# Altium schematic sheet L6790A_Si.SchDoc
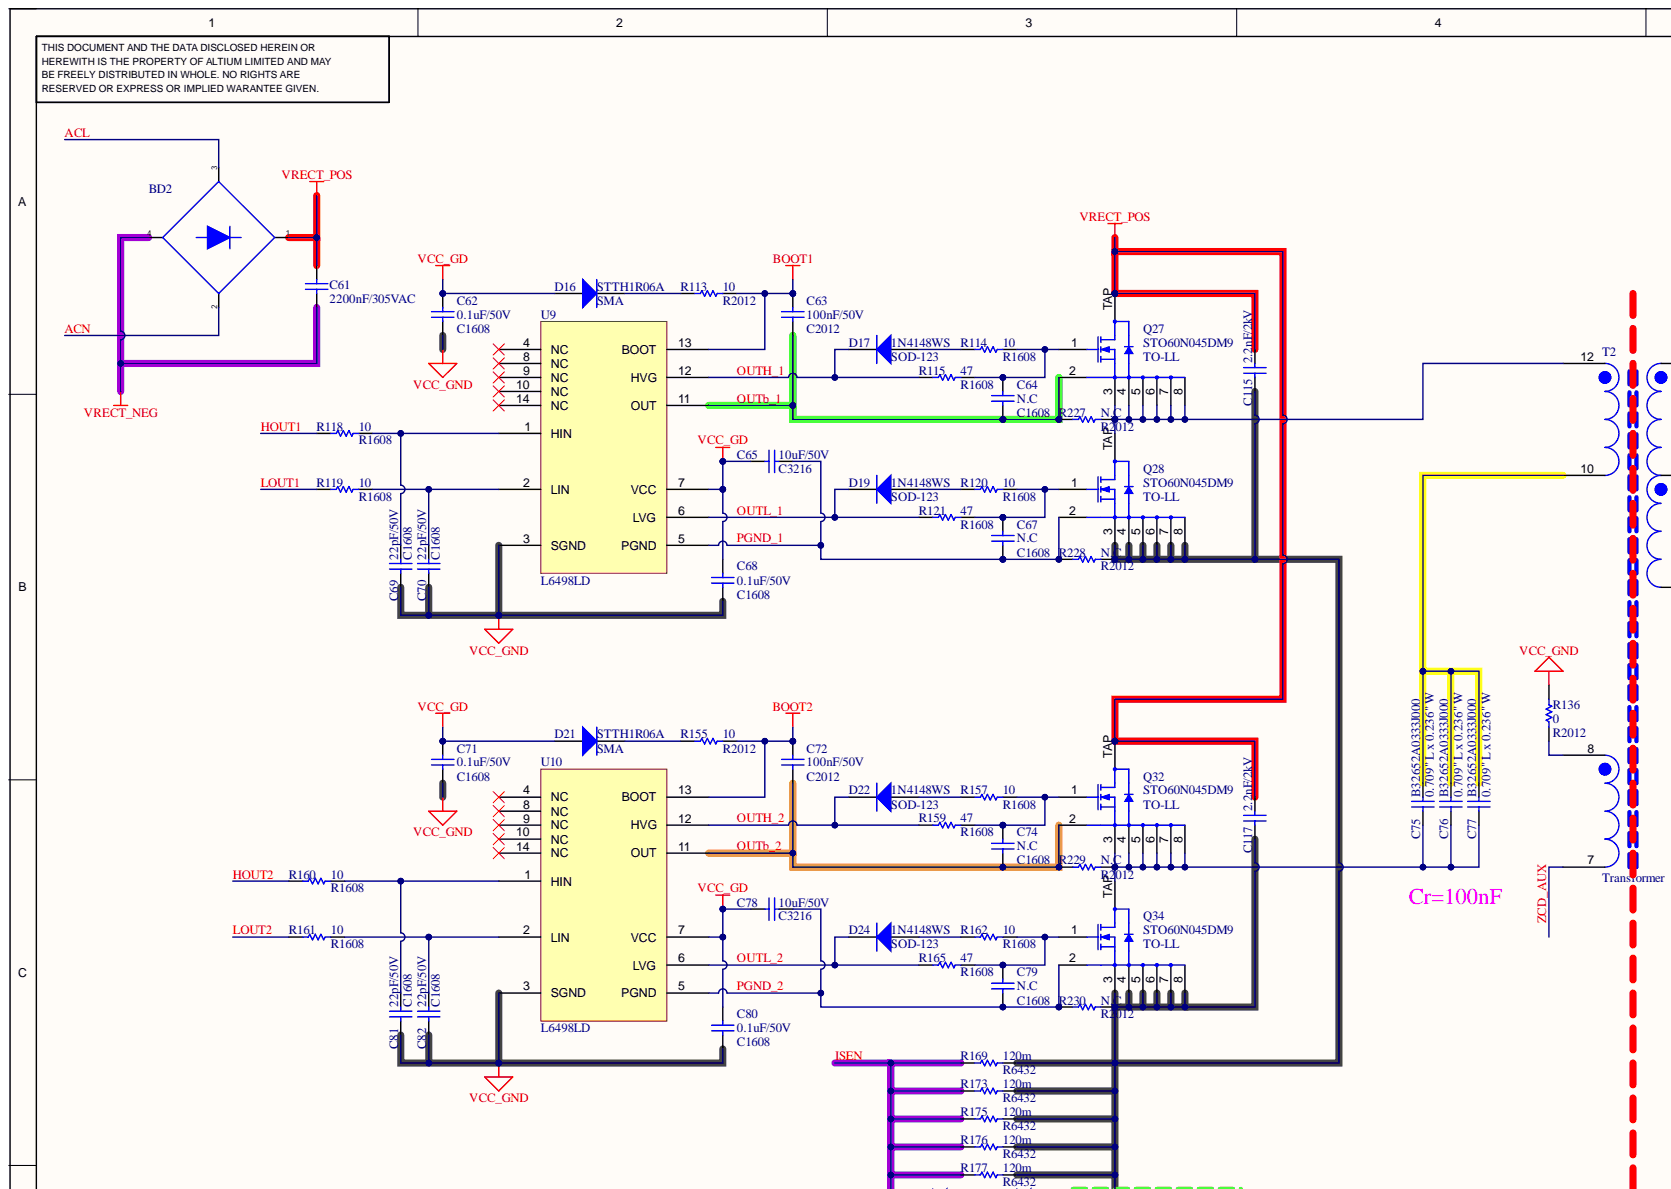
[diagram p0: L6790A_Si.SchDoc, top left]
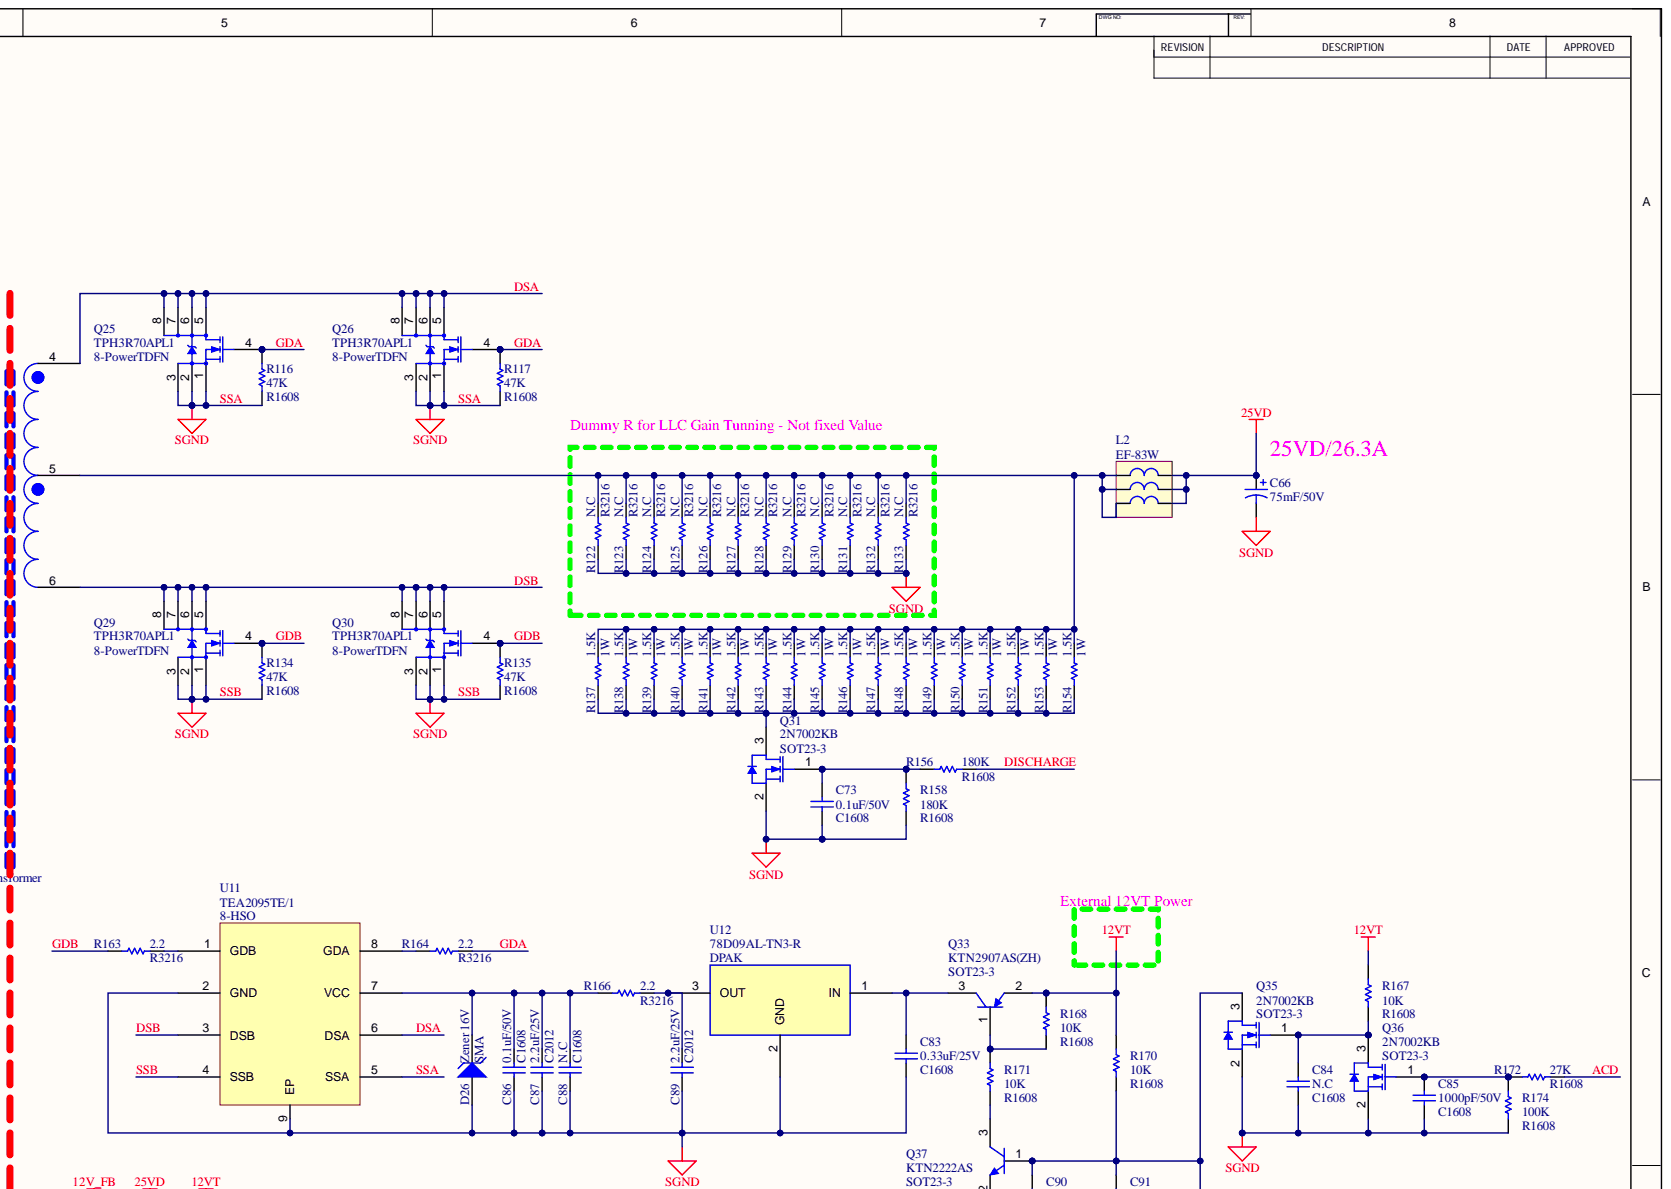
[diagram p1: L6790A_Si.SchDoc, top right]
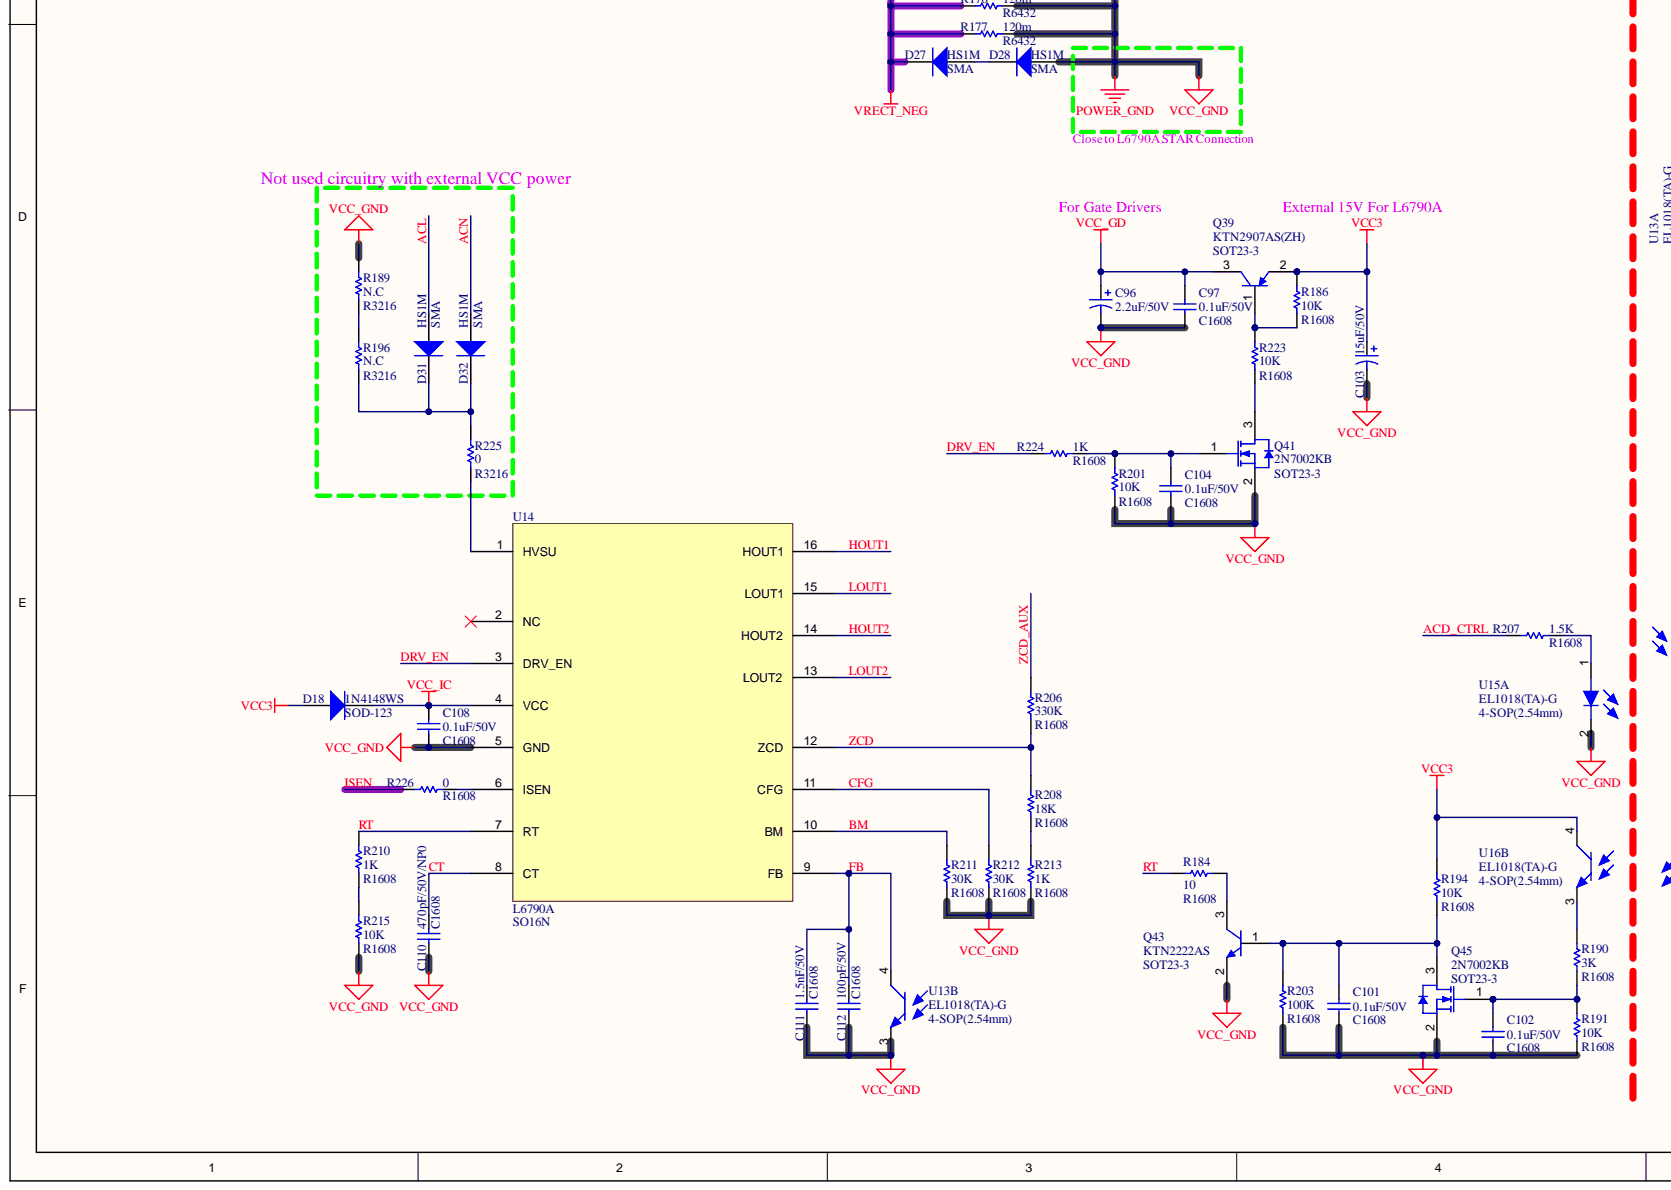
[diagram p2: L6790A_Si.SchDoc, bottom left]
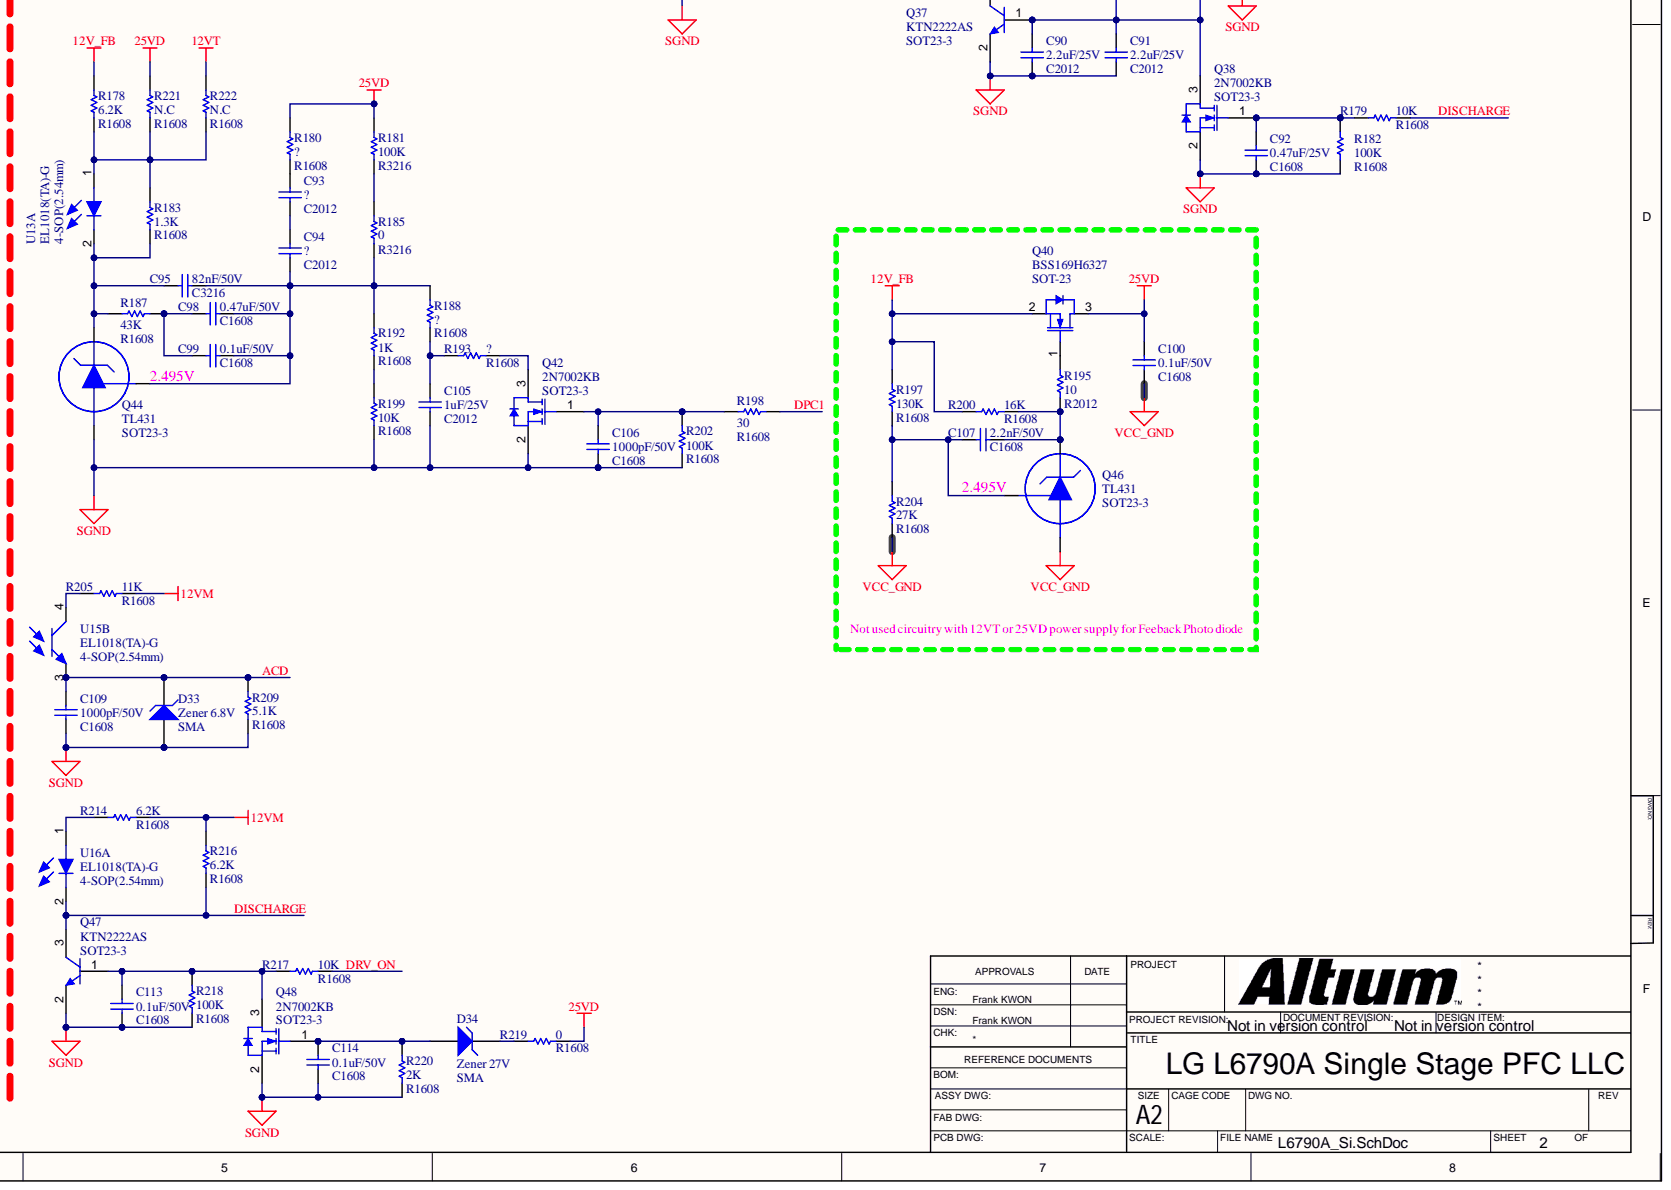
[diagram p3: L6790A_Si.SchDoc, bottom right]

## Parts
BD2  TS6KL60  [2W-DIO-MI-4L-4_6X25X15-7_5P-BD]  (value not drawn)
C61  2200nF/305VAC
C62  0.1uF/50V  Package/Case: C1608
C63  100nF/50V  Package/Case: C2012
C64  N.C  Package/Case: C1608
C65  10uF/50V  Package/Case: C3216
C66  75mF/50V  [1W-CAP-MI-2L-16DX25-7_5P-EL-F-R1212]
C67  N.C  Package/Case: C1608
C68  0.1uF/50V  Package/Case: C1608
C69  22pF/50V  Package/Case: C1608
C70  22pF/50V  Package/Case: C1608
C71  0.1uF/50V  Package/Case: C1608
C72  100nF/50V  Package/Case: C2012
C73  0.1uF/50V  Package/Case: C1608
C74  N.C  Package/Case: C1608
C75  B32652A0333J000
C76  B32652A0333J000
C77  B32652A0333J000
C78  10uF/50V  Package/Case: C3216
C79  N.C  Package/Case: C1608
C80  0.1uF/50V  Package/Case: C1608
C81  22pF/50V  Package/Case: C1608
C82  22pF/50V  Package/Case: C1608
C83  0.33uF/25V  Package/Case: C1608
C84  N.C  Package/Case: C1608
C85  1000pF/50V  Package/Case: C1608
C86  0.1uF/50V  Package/Case: C1608
C87  2.2uF/25V  Package/Case: C2012
C88  N.C  Package/Case: C1608
C89  2.2uF/25V  Package/Case: C2012
C90  2.2uF/25V  Package/Case: C2012
C91  2.2uF/25V  Package/Case: C2012
C92  0.47uF/25V  Package/Case: C1608
C93  ?  Package/Case: C2012
C94  ?  Package/Case: C2012
C95  82nF/50V  Package/Case: C3216  [CAP-CHP-3216-R0]
C96  2.2uF/50V  [CAP-RA-D5.0H12_5-P2-R0CA]
C97  0.1uF/50V  Package/Case: C1608
C98  0.47uF/50V  Package/Case: C1608
C99  0.1uF/50V  Package/Case: C1608
C100  0.1uF/50V  Package/Case: C1608
C101  0.1uF/50V  Package/Case: C1608
C102  0.1uF/50V  Package/Case: C1608
C103  15uF/50V  [CAP-RA-D5.0H12_5-P2-R0CA]
C104  0.1uF/50V  Package/Case: C1608
C105  1uF/25V  Package/Case: C2012
C106  1000pF/50V  Package/Case: C1608
C107  2.2nF/50V  Package/Case: C1608
C108  0.1uF/50V  Package/Case: C1608
C109  1000pF/50V  Package/Case: C1608
C110  470pF/50V/NP0  Package/Case: C1608
C111  1.5nF/50V  Package/Case: C1608
C112  100pF/50V  Package/Case: C1608
C113  0.1uF/50V  Package/Case: C1608
C114  0.1uF/50V  Package/Case: C1608
C115  2.2nF/2kV
C117  2.2nF/2kV
D16  STTH1R06A  Package/Case: SMA  [DIO-SOD123]
D17  1N4148WS  Package/Case: SOD-123  [DIO-SOD123]
D18  1N4148WS  Package/Case: SOD-123  [DIO-SOD123]
D19  1N4148WS  Package/Case: SOD-123  [DIO-SOD123]
D21  STTH1R06A  Package/Case: SMA  [DIO-SOD123]
D22  1N4148WS  Package/Case: SOD-123  [DIO-SOD123]
D24  1N4148WS  Package/Case: SOD-123  [DIO-SOD123]
D26  Zener 16V  Package/Case: SMA  [1W-DIO-CHP-2L-SOD106-SMA]
D27  HS1M  Package/Case: SMA  [DIO-SOD123]
D28  HS1M  Package/Case: SMA  [DIO-SOD123]
D31  HS1M  Package/Case: SMA  [DIO-SOD123]
D32  HS1M  Package/Case: SMA  [DIO-SOD123]
D33  Zener 6.8V  Package/Case: SMA  [1W-DIO-CHP-2L-SOD106-SMA]
D34  Zener 27V  Package/Case: SMA  [1W-DIO-CHP-2L-SOD106-SMA]
L2  EF-83W
Q25  TPH3R70APL1  Package/Case: 8-PowerTDFN
Q26  TPH3R70APL1  Package/Case: 8-PowerTDFN
Q27  STO60N045DM9  Package/Case: TO-LL
Q28  STO60N045DM9  Package/Case: TO-LL
Q29  TPH3R70APL1  Package/Case: 8-PowerTDFN
Q30  TPH3R70APL1  Package/Case: 8-PowerTDFN
Q31  2N7002KB  Package/Case: SOT23-3
Q32  STO60N045DM9  Package/Case: TO-LL
Q33  KTN2907AS(ZH)  Package/Case: SOT23-3
Q34  STO60N045DM9  Package/Case: TO-LL
Q35  2N7002KB  Package/Case: SOT23-3
Q36  2N7002KB  Package/Case: SOT23-3
Q37  KTN2222AS  Package/Case: SOT23-3
Q38  2N7002KB  Package/Case: SOT23-3
Q39  KTN2907AS(ZH)  Package/Case: SOT23-3
Q40  BSS169H6327  Package/Case: SOT-23  [SOT-23_TR]
Q41  2N7002KB  Package/Case: SOT23-3
Q42  2N7002KB  Package/Case: SOT23-3
Q43  KTN2222AS  Package/Case: SOT23-3
Q44  TL431  Package/Case: SOT23-3  [SOT-23_TR]
Q45  2N7002KB  Package/Case: SOT23-3
Q46  TL431  Package/Case: SOT23-3  [SOT-23_TR]
Q47  KTN2222AS  Package/Case: SOT23-3
Q48  2N7002KB  Package/Case: SOT23-3
R113  10  Package/Case: R2012  [RES-1608]
R114  10  Package/Case: R1608  [RES-1608]
R115  47  Package/Case: R1608  [RES-1608]
R116  47K  Package/Case: R1608  [RES-1608]
R117  47K  Package/Case: R1608  [RES-1608]
R118  10  Package/Case: R1608  [RES-1608]
R119  10  Package/Case: R1608  [RES-1608]
R120  10  Package/Case: R1608  [RES-1608]
R121  47  Package/Case: R1608  [RES-1608]
R122  N.C  Package/Case: R3216  [RES-3216]
R123  N.C  Package/Case: R3216  [RES-3216]
R124  N.C  Package/Case: R3216  [RES-3216]
R125  N.C  Package/Case: R3216  [RES-3216]
R126  N.C  Package/Case: R3216  [RES-3216]
R127  N.C  Package/Case: R3216  [RES-3216]
R128  N.C  Package/Case: R3216  [RES-3216]
R129  N.C  Package/Case: R3216  [RES-3216]
R130  N.C  Package/Case: R3216  [RES-3216]
R131  N.C  Package/Case: R3216  [RES-3216]
R132  N.C  Package/Case: R3216  [RES-3216]
R133  N.C  Package/Case: R3216  [RES-3216]
R134  47K  Package/Case: R1608  [RES-1608]
R135  47K  Package/Case: R1608  [RES-1608]
R136  0  Package/Case: R2012  [RES-1608]
R137  1.5K  Package/Case: 1W
R138  1.5K  Package/Case: 1W
R139  1.5K  Package/Case: 1W
R140  1.5K  Package/Case: 1W
R141  1.5K  Package/Case: 1W
R142  1.5K  Package/Case: 1W
R143  1.5K  Package/Case: 1W
R144  1.5K  Package/Case: 1W
R145  1.5K  Package/Case: 1W
R146  1.5K  Package/Case: 1W
R147  1.5K  Package/Case: 1W
R148  1.5K  Package/Case: 1W
R149  1.5K  Package/Case: 1W
R150  1.5K  Package/Case: 1W
R151  1.5K  Package/Case: 1W
R152  1.5K  Package/Case: 1W
R153  1.5K  Package/Case: 1W
R154  1.5K  Package/Case: 1W
R155  10  Package/Case: R2012  [RES-1608]
R156  180K  Package/Case: R1608  [RES-1608]
R157  10  Package/Case: R1608  [RES-1608]
R158  180K  Package/Case: R1608  [RES-1608]
R159  47  Package/Case: R1608  [RES-1608]
R160  10  Package/Case: R1608  [RES-1608]
R161  10  Package/Case: R1608  [RES-1608]
R162  10  Package/Case: R1608  [RES-1608]
R163  2.2  Package/Case: R3216  [RES-3216]
R164  2.2  Package/Case: R3216  [RES-3216]
R165  47  Package/Case: R1608  [RES-1608]
R166  2.2  Package/Case: R3216  [RES-3216]
R167  10K  Package/Case: R1608  [RES-1608]
R168  10K  Package/Case: R1608  [RES-1608]
R169  120m  Package/Case: R6432
R170  10K  Package/Case: R1608  [RES-1608]
R171  10K  Package/Case: R1608  [RES-1608]
R172  27K  Package/Case: R1608  [RES-1608]
R173  120m  Package/Case: R6432
R174  100K  Package/Case: R1608  [RES-1608]
R175  120m  Package/Case: R6432
R176  120m  Package/Case: R6432
R177  120m  Package/Case: R6432
R178  6.2K  Package/Case: R1608  [RES-3216]
R179  10K  Package/Case: R1608  [RES-1608]
R180  ?  Package/Case: R1608  [RES-1608]
R181  100K  Package/Case: R3216  [RES-3216]
R182  100K  Package/Case: R1608  [RES-1608]
R183  1.3K  Package/Case: R1608  [RES-1608]
R184  10  [RES-1608]
R185  0  Package/Case: R3216  [RES-3216]
R186  10K  Package/Case: R1608  [RES-1608]
R187  43K  [RES-1608]
R188  ?  Package/Case: R1608  [RES-1608]
R189  N.C  Package/Case: R3216  [RES-3216]
R190  3K  Package/Case: R1608  [RES-1608]
R191  10K  Package/Case: R1608  [RES-1608]
R192  1K  Package/Case: R1608  [RES-1608]
R193  ?  Package/Case: R1608  [RES-1608]
R194  10K  Package/Case: R1608  [RES-1608]
R195  10  Package/Case: R2012  [RES-1608]
R196  N.C  Package/Case: R3216  [RES-3216]
R197  130K  Package/Case: R1608  [RES-1608]
R198  30  Package/Case: R1608  [RES-1608]
R199  10K  Package/Case: R1608  [RES-1608]
R200  16K  Package/Case: R1608  [RES-1608]
R201  10K  Package/Case: R1608  [RES-1608]
R202  100K  Package/Case: R1608  [RES-1608]
R203  100K  Package/Case: R1608  [RES-1608]
R204  27K  Package/Case: R1608  [RES-1608]
R205  11K  Package/Case: R1608  [RES-1608]
R206  330K  Package/Case: R1608  [RES-1608]
R207  1.5K  Package/Case: R1608  [RES-1608]
R208  18K  Package/Case: R1608  [RES-1608]
R209  5.1K  Package/Case: R1608  [RES-1608]
R210  1K  Package/Case: R1608  [RES-1608]
R211  30K  Package/Case: R1608  [RES-1608]
R212  30K  Package/Case: R1608  [RES-1608]
R213  1K  Package/Case: R1608  [RES-1608]
R214  6.2K  Package/Case: R1608  [RES-1608]
R215  10K  Package/Case: R1608  [RES-1608]
R216  6.2K  Package/Case: R1608  [RES-1608]
R217  10K  Package/Case: R1608  [RES-1608]
R218  100K  Package/Case: R1608  [RES-1608]
R219  0  Package/Case: R1608  [RES-1608]
R220  2K  Package/Case: R1608  [RES-1608]
R221  N.C  Package/Case: R1608  [RES-3216]
R222  N.C  Package/Case: R1608  [RES-3216]
R223  10K  Package/Case: R1608  [RES-1608]
R224  1K  Package/Case: R1608  [RES-1608]
R225  0  Package/Case: R3216  [RES-1608]
R226  0  Package/Case: R1608  [RES-1608]
R227  N.C  Package/Case: R2012  [RES-1608]
R228  N.C  Package/Case: R2012  [RES-1608]
R229  N.C  Package/Case: R2012  [RES-1608]
R230  N.C  Package/Case: R2012  [RES-1608]
T2  Transformer
U9  L6498LD
U10  L6498LD
U11  TEA2095TE/1  Package/Case: 8-HSO
U12  78D09AL-TN3-R  Package/Case: DPAK
U13  EL1018(TA)-G  Package/Case: 4-SOP(2.54mm)  [1W-IC-CHP-4L-3_6X7_6-2_54P-L10_2-PC]  (parts A,B)
U14  L6790A  Package/Case: SO16N
U15  EL1018(TA)-G  Package/Case: 4-SOP(2.54mm)  [1W-IC-CHP-4L-3_6X7_6-2_54P-L10_2-PC]  (parts A,B)
U16  EL1018(TA)-G  Package/Case: 4-SOP(2.54mm)  [1W-IC-CHP-4L-3_6X7_6-2_54P-L10_2-PC]  (parts A,B)

## Nets
12V_FB: Q40.2 R178.2 R197.1 R200.2
12VM: R205.2 R214.2 R216.2
12VT: Q33.2 R167.1 R168.1 R170.1 R222.2
25VD: C66.1 C100.1 L2.2 Q40.3 R180.2 R181.1 R219.2 R221.2
BOOT1: C63.1 R113.2 U9.13(BOOT)
BOOT2: C72.1 R155.2 U10.13(BOOT)
POWER_GND: C62.1 C68.2 C69.2 C70.2 C71.1 C80.2 C81.2 C82.2 C96.2 C97.2 C100.2 C101.2 C102.2 C103.2 C104.2 C108.2 C110.2 C111.2 C112.2 C115.2 C117.2 D28.1 Q28.3 Q28.4 Q28.5 Q28.6 Q28.7 Q28.8 Q34.3 Q34.4 Q34.5 Q34.6 Q34.7 Q34.8 Q41.2 Q43.2 Q45.2 Q46.3 R136.2 R169.1 R173.1 R175.1 R176.1 R177.1 R189.2 R191.2 R201.2 R203.2 R204.2 R211.2 R212.2 R213.2 R215.2 R228.2 R230.2 U9.3(SGND) U10.3(SGND) U13.3 U14.5(GND) U15.2
VCC3: C103.1 D18.1 Q39.2 R186.1 R194.1 U16.4
VCC_GD: C62.2 C65.1 C68.1 C71.2 C78.1 C80.1 C96.1 C97.1 D16.1 D21.1 Q39.3 U9.7(VCC) U10.7(VCC)
VCC_IC: C108.1 D18.2 U14.4(VCC)
VRECT_POS: BD2.1 C61.2 C115.1 C117.1 Q27.TAP Q32.TAP
ACD: C109.1 D33.2 R172.2 R209.2 U15.3
ACD_CTRL: R207.2
ACL: BD2.3 D31.1
ACN: BD2.2 D32.1
BM: R211.1 U14.10(BM)
CFG: R212.1 U14.11(CFG)
CT: C110.1 U14.8(CT)
DISCHARGE: Q47.3 R156.2 R179.2 R216.1 U16.2
DPC1: R198.2
DRV_EN: R224.1 U14.3(DRV_EN)
DRV_ON: R217.2
DSA: Q25.5 Q25.6 Q25.7 Q25.8 Q26.5 Q26.6 Q26.7 Q26.8 T2.4 U11.6(DSA)
DSB: Q29.5 Q29.6 Q29.7 Q29.8 Q30.5 Q30.6 Q30.7 Q30.8 T2.6 U11.3(DSB)
FB: C111.1 C112.1 U13.4 U14.9(FB)
GDA: Q25.4 Q26.4 R116.1 R117.1 R164.1
GDB: Q29.4 Q30.4 R134.1 R135.1 R163.2
HOUT1: R118.1 U14.16(HOUT1)
HOUT2: R160.1 U14.14(HOUT2)
ISEN: BD2.4 C61.1 D27.2 R169.2 R173.2 R175.2 R176.2 R177.2 R226.1
LOUT1: R119.1 U14.15(LOUT1)
LOUT2: R161.1 U14.13(LOUT2)
OUTb_1: C63.2 C64.2 Q27.2 R227.1 U9.11(OUT)
OUTb_2: C72.2 C74.2 Q32.2 R229.1 U10.11(OUT)
OUTH_1: D17.2 R115.2 U9.12(HVG)
OUTH_2: D22.2 R159.2 U10.12(HVG)
OUTL_1: D19.2 R121.2 U9.6(LVG)
OUTL_2: D24.2 R165.2 U10.6(LVG)
PGND_1: C65.2 C67.2 Q28.2 R228.1 U9.5(PGND)
PGND_2: C78.2 C79.2 Q34.2 R230.1 U10.5(PGND)
RT: R184.2 R210.1 U14.7(RT)
SSA: C66.2 C73.2 C83.2 C84.2 C85.2 C86.2 C87.2 C88.2 C89.2 C90.2 C91.2 C92.2 C105.2 C106.2 C109.2 C113.1 C114.1 D26.1 D33.1 Q25.1 Q25.2 Q25.3 Q26.1 Q26.2 Q26.3 Q29.1 Q29.2 Q29.3 Q30.1 Q30.2 Q30.3 Q31.2 Q35.2 Q36.2 Q37.2 Q38.2 Q42.2 Q44.3 Q47.2 Q48.2 R116.2 R117.2 R122.1 R123.1 R124.1 R125.1 R126.1 R127.1 R128.1 R129.1 R130.1 R131.1 R132.1 R133.1 R134.2 R135.2 R158.2 R174.2 R182.2 R199.2 R202.1 R209.1 R218.1 R220.1 U11.2(GND) U11.4(SSB) U11.5(SSA) U11.9(EP) U12.2
ZCD: R206.2 R208.1 U14.12(ZCD)
ZCD_AUX: R206.1 T2.7
NetC64_1: C64.1 Q27.1 R114.1 R115.1
NetC67_1: C67.1 Q28.1 R120.1 R121.1
NetC69_1: C69.1 R118.2 U9.1(HIN)
NetC70_1: C70.1 R119.2 U9.2(LIN)
NetC73_1: C73.1 Q31.1 R156.1 R158.1
NetC74_1: C74.1 Q32.1 R157.1 R159.1
NetC75_1: C75.1 C76.1 C77.1 T2.10
NetC75_2: C75.2 C76.2 C77.2 Q32.3 Q32.4 Q32.5 Q32.6 Q32.7 Q32.8 Q34.TAP R229.2
NetC79_1: C79.1 Q34.1 R162.1 R165.1
NetC81_1: C81.1 R160.2 U10.1(HIN)
NetC82_1: C82.1 R161.2 U10.2(LIN)
NetC83_1: C83.1 Q33.3 U12.1
NetC84_1: C84.1 Q35.1 Q36.3 R167.2
NetC85_1: C85.1 Q36.1 R172.1 R174.1
NetC86_1: C86.1 C87.1 C88.1 D26.2 R166.2 U11.7(VCC)
NetC89_1: C89.1 R166.1 U12.3
NetC90_1: C90.1 C91.1 Q35.3 Q37.1 Q38.3 R170.2
NetC92_1: C92.1 Q38.1 R179.1 R182.1
NetC93_1: C93.1 R180.1
NetC93_2: C93.2 C94.1
NetC94_2: C94.2 C95.1 C98.1 C99.1 Q44.1 R185.2 R188.2 R192.1
NetC95_2: C95.2 Q44.2 R183.2 R187.1 U13.2
NetC98_2: C98.2 C99.2 R187.2
NetC101_1: C101.1 Q43.1 Q45.3 R194.2 R203.1
NetC102_1: C102.1 Q45.1 R190.2 R191.1
NetC104_1: C104.1 Q41.1 R201.1 R224.2
NetC105_1: C105.1 R188.1 R193.1
NetC106_1: C106.1 Q42.1 R198.1 R202.2
NetC107_1: C107.1 Q46.1 R197.2 R204.1
NetC107_2: C107.2 Q46.2 R195.2 R200.1
NetC113_2: C113.2 Q47.1 Q48.3 R217.1 R218.2
NetC114_2: C114.2 D34.1 Q48.1 R220.2
NetD16_2: D16.2 R113.1
NetD17_1: D17.1 R114.2
NetD19_1: D19.1 R120.2
NetD21_2: D21.2 R155.1
NetD22_1: D22.1 R157.2
NetD24_1: D24.1 R162.2
NetD27_1: D27.1 D28.2
NetD31_2: D31.2 D32.2 R196.1 R225.2
NetD34_2: D34.2 R219.1
NetL2_1: L2.1 R122.2 R123.2 R124.2 R125.2 R126.2 R127.2 R128.2 R129.2 R130.2 R131.2 R132.2 R133.2 R137.2 R138.2 R139.2 R140.2 R141.2 R142.2 R143.2 R144.2 R145.2 R146.2 R147.2 R148.2 R149.2 R150.2 R151.2 R152.2 R153.2 R154.2 T2.5
NetQ27_3: Q27.3 Q27.4 Q27.5 Q27.6 Q27.7 Q27.8 Q28.TAP R227.2 T2.12
NetQ31_3: Q31.3 R137.1 R138.1 R139.1 R140.1 R141.1 R142.1 R143.1 R144.1 R145.1 R146.1 R147.1 R148.1 R149.1 R150.1 R151.1 R152.1 R153.1 R154.1
NetQ33_1: Q33.1 R168.2 R171.1
NetQ37_3: Q37.3 R171.2
NetQ39_1: Q39.1 R186.2 R223.1
NetQ40_1: Q40.1 R195.1
NetQ41_3: Q41.3 R223.2
NetQ42_3: Q42.3 R193.2
NetQ43_3: Q43.3 R184.1
NetR136_1: R136.1 T2.8
NetR163_1: R163.1 U11.1(GDB)
NetR164_2: R164.2 U11.8(GDA)
NetR178_1: R178.1 R183.1 R221.1 R222.1 U13.1
NetR181_2: R181.2 R185.1
NetR189_1: R189.1 R196.2
NetR190_1: R190.1 U16.3
NetR192_2: R192.2 R199.1
NetR205_1: R205.1 U15.4
NetR207_1: R207.1 U15.1
NetR208_2: R208.2 R213.1
NetR210_2: R210.2 R215.1
NetR214_1: R214.1 U16.1
NetR225_1: R225.1 U14.1(HVSU)
NetR226_2: R226.2 U14.6(ISEN)

Not connected: U9 4(NC) 8(NC) 9(NC) 10(NC) 14(NC); U10 4(NC) 8(NC) 9(NC) 10(NC) 14(NC); U14 2(NC)

## Library data of the parts
BD2 = BRIDGE: BRIDGE DIODE; Component Kind: Standard; Library Name: Schematic.SCHLIB; Mount Type: DIP
C61-C65 C67-C95 C97-C102 C104-C115 C117 = Cap: Chip MLCC; Component Kind: Standard; Library Name: Miscellaneous Devices.IntLib
  C61-C65 C67-C74 C78-C92 C97 C100-C102 C104 C106-C115 C117: Mounting Type: SMD
  C75-C77: Mounting Type: SMD; Package/Case: 0.709" L x 0.236" W
  C93 C94 C98 C99 C105: Mount Type: SMD
  C95: Mount Type: SMD; Simulation: CAP
C66 = Cap Pol1: Electrolytic Capacitor; Component Kind: Standard; Library Name: Miscellaneous Devices.IntLib; Mount Type: DIP; Simulation: CAP
C96 C103 = Cap Pol1: Electrolytic Capacitor 33uF/25V; Component Kind: Standard; Library Name: Miscellaneous Devices.IntLib; Mount Type: DIP; Simulation: CAP
D16-D19 D21 D22 D24 D27 D28 D31 D32 = Diode 1N4148: DIODE SCHOTTKY 30V 200MA SOD123; Component Kind: Standard; Library Name: Miscellaneous Devices.IntLib; Mount Type: SMD; Simulation: 1N4148
D26 D33 D34 = D Zener: DIODE ZENER 30V 1W DO214AC; Component Kind: Standard; Library Name: Miscellaneous Devices.IntLib; Mount Type: SMD; Simulation: ZENER
Q25 Q26 Q29 Q30 = TPH3R70APL1: N-Channel 100 V 90A (Tc) 210W (Tc) Surface Mount 8-SOP Advance (5x5.75); Component Kind: Standard; Library Name: Schematic.SCHLIB; Mount Type: SMD
Q27 Q28 Q32 Q34 = STO60N045DM9: N‑channel 600 V, 35 mΩ typ., 56 A MDmesh DM9 Power MOSFET in a TO‑LL package; Component Kind: Standard; Library Name: Schematic.SCHLIB; Mount Type: SMD
Q31 Q35 Q36 Q38 Q41 Q42 Q45 Q48 = 2N7002KB: N-CH MOSFET; Component Kind: Standard; Library Name: Schematic.SCHLIB; Mounting Type: SMD
Q33 Q39 = ONSC-PNP-B1E2C3-3: PNP BJT; Component Kind: Standard; Library Name: Schematic.SCHLIB; Mounting Type: SMD
Q37 Q43 Q47 = ONSC-NPN-B1E2C3-3: BJT NPN; Component Kind: Standard; Library Name: Schematic.SCHLIB; Mounting Type: SMD
Q40 = CMP-32901-000982-1: MOSFET N-CH 100V 170MA SOT23-3; Component Kind: Standard; Library Name: Miscellaneous Devices.IntLib; Mount Type: SMD
Q44 Q46 = 1W-IC-CHP-3L-SOT23-SCR-R0: IC VREF SHUNT ADJ 1% SOT23-3; Component Kind: Standard; Mount Type: SMD
R113-R136 R155-R230 = Res1: Chip Resistor; Component Kind: Standard; Library Name: Miscellaneous Devices.IntLib; Mount Type: SMD; Simulation: RESISTOR
  R184 R187: Package/Case: R1608
R137-R154 = Res1: Watt Resistor; Component Kind: Standard; Library Name: Miscellaneous Devices.IntLib; Mount Type: SMD; Simulation: RESISTOR
U11 = TEA2095TE/1: LLC SR CONTROLLER IC; Component Kind: Standard; Library Name: Schematic.SCHLIB; Mounting Type: SMD
U12 = 78D09AL-TN3-R: 3-TERMINALS 1A POSITIVE VOLTAGE REGULATOR; Component Kind: Standard; Library Name: Schematic.SCHLIB; Mounting Type: SMD
U13 U15 U16 = SFH617A-2X019T: Photocoupler; Component Kind: Standard; Library Name: SFH617A-2X019T.SchLib; Mount Type: SMD
U14 = L6790A: LLC-PFC controller IC for insulated power factor corrector application; Component Kind: Standard; Library Name: Schematic.SCHLIB; Mounting Type: SMD
L2 = EF-83W: Component Kind: Standard; Library Name: Schematic.SCHLIB
T2 = Transformer: Component Kind: Standard; Description: Transformer; Library Name: Schematic.SCHLIB; Mount Type: DIP
U9 U10 = L6498LD: Component Kind: Standard; Library Name: Schematic.SCHLIB
